# Altium schematic sheet UART.SchDoc(UART)
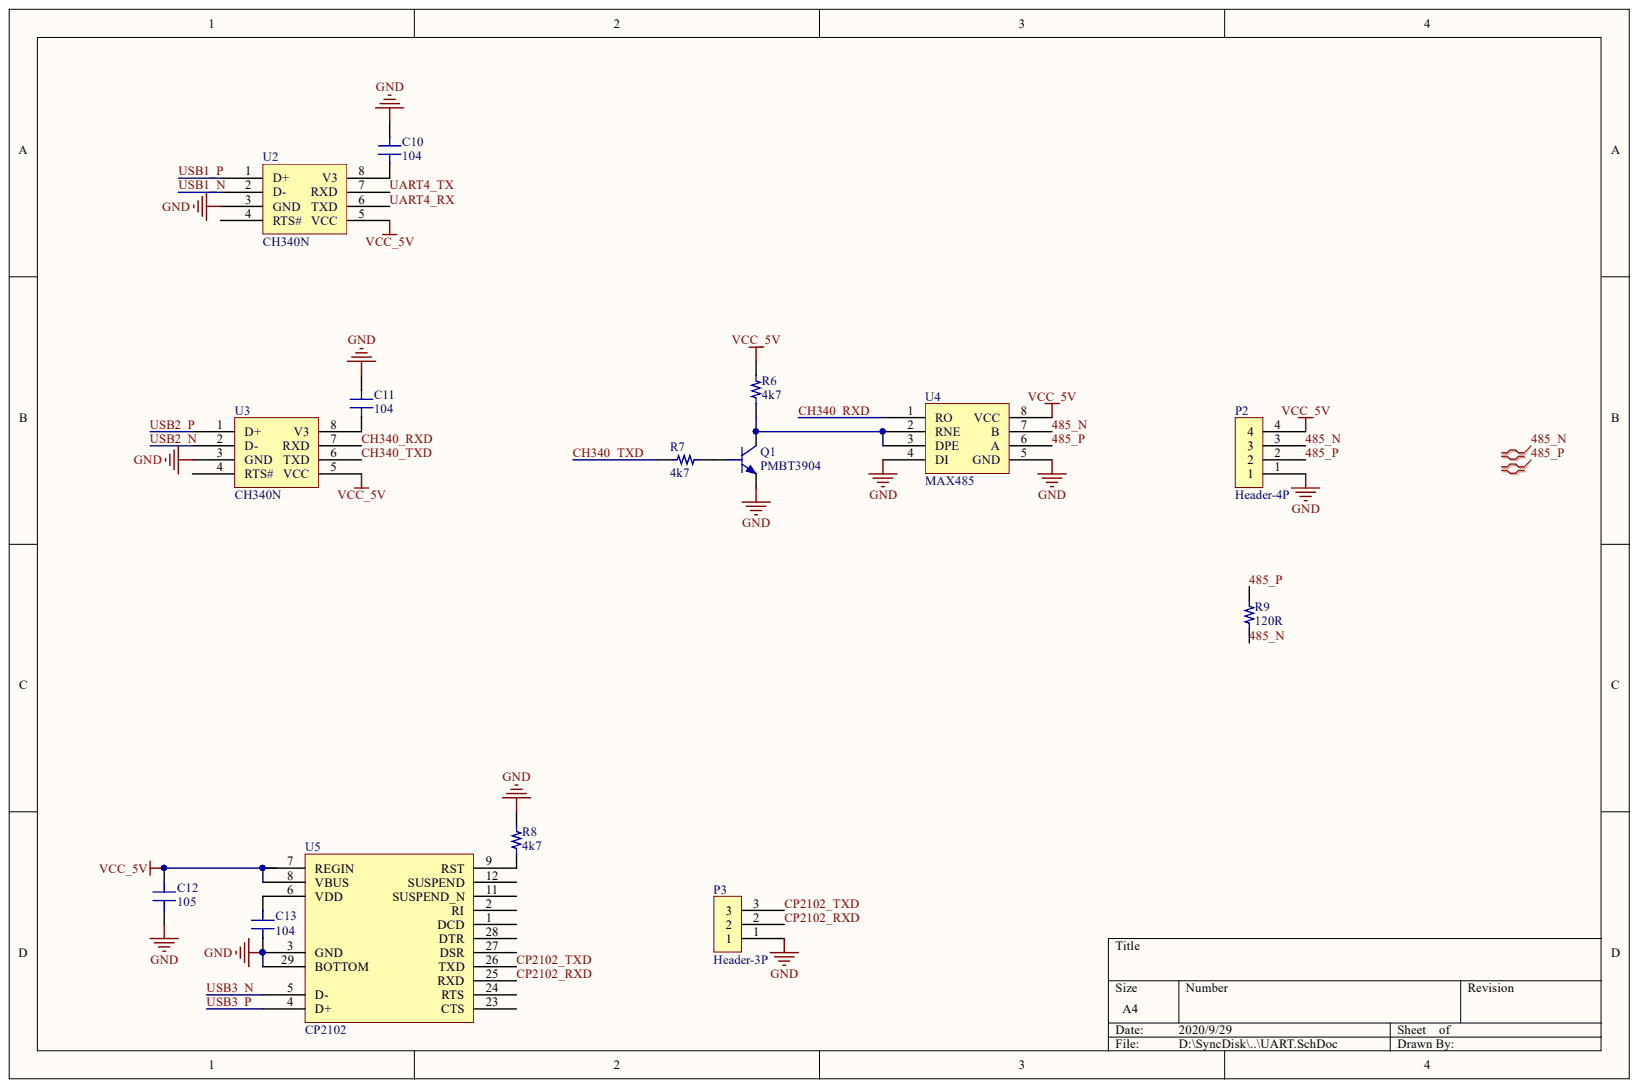
[diagram p0: UART.SchDoc]

## Parts
C10  104  [C0603/1608]
C11  104  [C0603/1608]
C12  105  [C0603/1608]
C13  104  [C0603/1608]
P2  Header-4P  [Hole-4P]
P3  Header-3P  [HDR2.54-WI-3P]
Q1  PMBT3904  [SOT-23-3]
R6  4k7  [R0603/1608]
R7  4k7  [R0603/1608]
R8  4k7  [R0603/1608]
R9  120R  [R0603/1608]
U2  CH340N  [SOP-8]
U3  CH340N  [SOP-8]
U4  MAX485  [SOP-8]
U5  CP2102  [QFN50P500X500-28VAM]

## Nets
GND: C10.2 C11.2 C12.1 C13.1 P2.1 P3.1 Q1.2 R8.2 U2.3(GND) U3.3(GND) U4.4(DI) U4.5(GND) U5.3(GND) U5.29(BOTTOM)
VCC_5V: C12.2 P2.4 R6.1 U2.5(VCC) U3.5(VCC) U4.8(VCC) U5.7(REGIN) U5.8(VBUS)
485_N: P2.3 R9.1 U4.7(B)
485_P: P2.2 R9.2 U4.6(A)
CH340_RXD: U3.7(RXD) U4.1(RO)
CH340_TXD: R7.1 U3.6(TXD)
CP2102_RXD: P3.2 U5.25(RXD)
CP2102_TXD: P3.3 U5.26(TXD)
UART4_RX: U2.6(TXD)
UART4_TX: U2.7(RXD)
USB1_N: U2.2(D-)
USB1_P: U2.1(D+)
USB2_N: U3.2(D-)
USB2_P: U3.1(D+)
USB3_N: U5.5(D-)
USB3_P: U5.4(D+)
NetC10_1: C10.1 U2.8(V3)
NetC11_1: C11.1 U3.8(V3)
NetC13_2: C13.2 U5.6(VDD)
NetQ1_1: Q1.1 R7.2
NetQ1_3: Q1.3 R6.2 U4.2(RNE) U4.3(DPE)
NetR8_1: R8.1 U5.9(RST)

Not connected: U2 4(RTS#); U3 4(RTS#); U5 1(DCD) 2(RI) 11(SUSPEND_N) 12(SUSPEND) 23(CTS) 24(RTS) 27(DSR) 28(DTR)

## Library data of the parts
C10-C13 = 陶瓷电容: Component Kind: Standard; Description: 陶瓷电容; Library Name: WMJ2019_Devices.SchLib
Q1 = NPN: NPN三极管; Component Kind: Standard; LatestRevisionDate: 29-May-2009; LatestRevisionNote: IPC-7351 Footprint Added.; Library Name: Devices.SchLib; PackageDescription: 3-Pin SOT-23 Package 0.95 mm Pitch, 2.4 mm Lead Span; PackageReference: SOT-23B; PackageVersion: Aug-1999; Published: 8-Jun-2000; Publisher: Altium Limited
R6-R9 = 电阻: Component Kind: Standard; Description: 电阻; Library Name: Devices.SchLib
U2 U3 = CH340N: USB-TTL转换芯片; Component Kind: Standard; Library Name: Devices.SchLib
P2 = Header-4P: Component Kind: Standard; Description: Header-4P; Library Name: Connectors.SchLib
P3 = Header-3P: Component Kind: Standard; Description: Header-3P; Library Name: Connectors.SchLib
U4 = MAX485: Component Kind: Standard; Library Name: Devices.SchLib
U5 = CP2102: Component Kind: Standard; Library Name: Devices.SchLib
